# Altium schematic sheet Sheet1.SchDoc
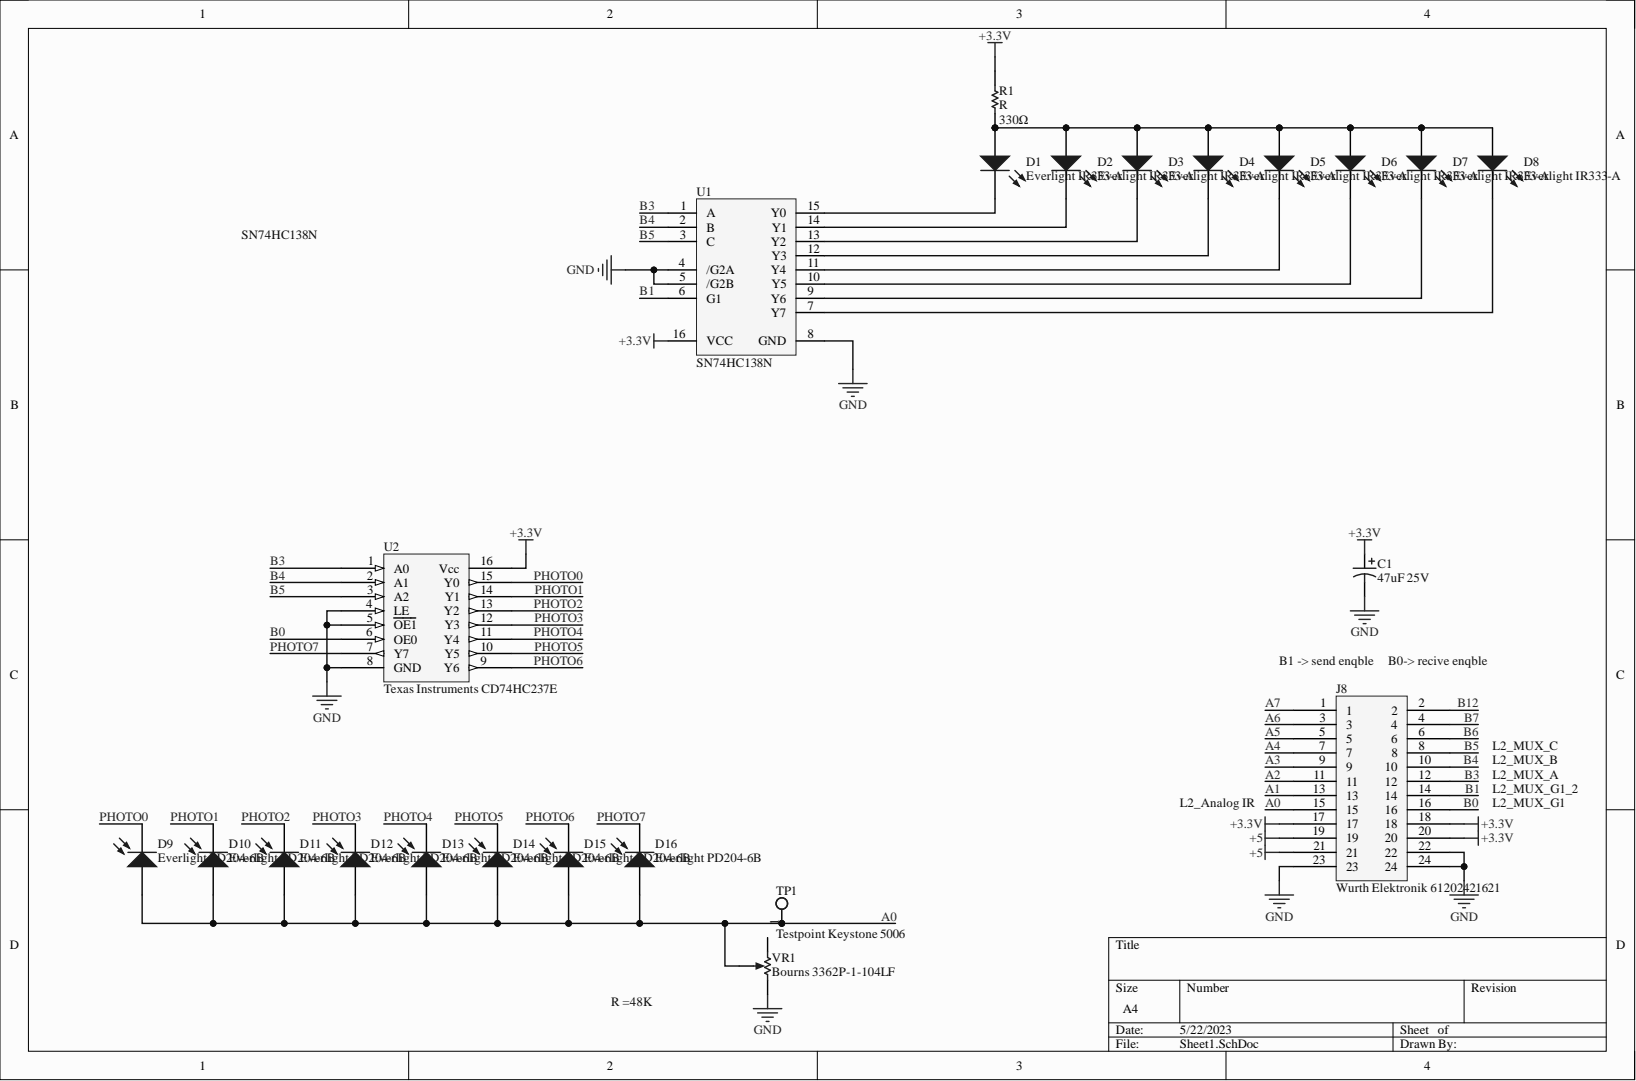
[diagram p0: Sheet1.SchDoc]

## Parts
C1  47uF 25V  [ELCO-6.3-12-2.5]
D1  Everlight IR333-A  [PCB-0002-02006-1]
D2  Everlight IR333-A  Manufacturer Part Number: IR333-A  [PCB-0002-02006-1]
D3  Everlight IR333-A  Manufacturer Part Number: IR333-A  [PCB-0002-02006-1]
D4  Everlight IR333-A  Manufacturer Part Number: IR333-A  [PCB-0002-02006-1]
D5  Everlight IR333-A  Manufacturer Part Number: IR333-A  [PCB-0002-02006-1]
D6  Everlight IR333-A  Manufacturer Part Number: IR333-A  [PCB-0002-02006-1]
D7  Everlight IR333-A  Manufacturer Part Number: IR333-A  [PCB-0002-02006-1]
D8  Everlight IR333-A  Manufacturer Part Number: IR333-A  [PCB-0002-02006-1]
D9  Everlight PD204-6B  [PCB-o05zkobulbt54tiv6vow-1]
D10  Everlight PD204-6B  Manufacturer Part Number: PD204-6B  [PCB-o05zkobulbt54tiv6vow-1]
D11  Everlight PD204-6B  Manufacturer Part Number: PD204-6B  [PCB-o05zkobulbt54tiv6vow-1]
D12  Everlight PD204-6B  Manufacturer Part Number: PD204-6B  [PCB-o05zkobulbt54tiv6vow-1]
D13  Everlight PD204-6B  Manufacturer Part Number: PD204-6B  [PCB-o05zkobulbt54tiv6vow-1]
D14  Everlight PD204-6B  Manufacturer Part Number: PD204-6B  [PCB-o05zkobulbt54tiv6vow-1]
D15  Everlight PD204-6B  Manufacturer Part Number: PD204-6B  [PCB-o05zkobulbt54tiv6vow-1]
D16  Everlight PD204-6B  Manufacturer Part Number: PD204-6B  [PCB-o05zkobulbt54tiv6vow-1]
J8  Wurth Elektronik 61202421621  Manufacturer Part Number: 61202421621  [Wurth Elektronik 61202421621-Footprint-1]
R1  R  Resistance: 330Ω  [PCB-q66ntzze7gytxksj4v9p-1]
TP1  Testpoint Keystone 5006  [PCB-a6m4wwq1w8vztsn322mx-1]
U1  SN74HC138N  [PCC-0018-00354-1]
U2  Texas Instruments CD74HC237E  Manufacturer Part Number: CD74HC237E  [PCB-xn33fkvk0f55jag7q4eg-1]
VR1  Bourns 3362P-1-104LF  [BOUR-3362P]

## Nets
+3.3V: C1.1 J8.17 J8.18 J8.20 R1.2 U1.16(VCC) U2.16(Vcc)
+5: J8.19 J8.21
GND: C1.2 J8.22 J8.23 J8.24 U1.4(/G2A) U1.5(/G2B) U1.8(GND) U2.4(LE) U2.5(OE1) U2.8(GND) VR1.3
A0: D9.1 D10.1 D11.1 D12.1 D13.1 D14.1 D15.1 D16.1 J8.15 TP1.1 VR1.2
A1: J8.13
A2: J8.11
A3: J8.9
A4: J8.7
A5: J8.5
A6: J8.3
A7: J8.1
B0: J8.16 U2.6(OE0)
B1: J8.14 U1.6(G1)
B3: J8.12 U1.1(A) U2.1(A0)
B4: J8.10 U1.2(B) U2.2(A1)
B5: J8.8 U1.3(C) U2.3(A2)
B6: J8.6
B7: J8.4
B12: J8.2
PHOTO0: D9.2 U2.15(Y0)
PHOTO1: D10.2 U2.14(Y1)
PHOTO2: D11.2 U2.13(Y2)
PHOTO3: D12.2 U2.12(Y3)
PHOTO4: D13.2 U2.11(Y4)
PHOTO5: D14.2 U2.10(Y5)
PHOTO6: D15.2 U2.9(Y6)
PHOTO7: D16.2 U2.7(Y7)
NetD1_1: D1.1 D2.1 D3.1 D4.1 D5.1 D6.1 D7.1 D8.1 R1.1
NetD1_2: D1.2 U1.15(Y0)
NetD2_2: D2.2 U1.14(Y1)
NetD3_2: D3.2 U1.13(Y2)
NetD4_2: D4.2 U1.12(Y3)
NetD5_2: D5.2 U1.11(Y4)
NetD6_2: D6.2 U1.10(Y5)
NetD7_2: D7.2 U1.9(Y6)
NetD8_2: D8.2 U1.7(Y7)

Not connected: VR1 1

## Library data of the parts
C1 = CMP-f0e23b7c3dc72f25-3: 150uF 6.3mm diameter 2.5mm lead spacing; Manufacturer Part Number: ECE-A1EKA470I; Capacitance: 47.0 µF; Capacitance Tolerance: ±20%; Lead-Free Status: Lead Free; Voltage Rating (DC): 25.0 V; Component Kind: Standard; Library Name: My Personal Space; Lifecycle Status: Not Listed by Manufacturer; Octopart Url: https://octopart.com/ece-a1eka470i-panasonic-43313219; OctopartID: f0e23b7c3dc72f25; Operating Temperature: -40.0 °C (min); Packaging: Cut Tape (CT); REACH SVHC Compliance: No SVHC; RoHS: Compliant; Size-Diameter: 6.30 mm; Size-Height: 7.00 mm
D1-D8 = CMP-906a59dde8874fad-1: 940 nm Viewing Angle 20° 100 mA Through Hole Plastic Infrared Emitting Diode; Lead-Free Status: Lead Free; Component Kind: Standard; Library Name: My Personal Space; Octopart URL: http://octopart.com/ir333-a-everlight-12410251; OctopartID: 906a59dde8874fad; RoHS: Compliant
  D1: Manufacturer Part Number: IR333-A
D9-D16 = CMP-5b29929d78a384ee-2: PD204 Series 6 ns 150 mW Photodiode Through Hole Photodetectors - RADIAL; Lead-Free Status: Lead Free; Component Kind: Standard; Library Name: My Personal Space; Mounting Style: Through Hole; Octopart Url: https://octopart.com/pd204-6b-everlight-12453612; OctopartID: 5b29929d78a384ee; RoHS: Compliant
  D9: Manufacturer Part Number: PD204-6B
J8 = CMP-5c4c94b32c98838b-3: WR-BHD Straight Male, Box Header, Through Hole, 2 Rows, 24 Contacts, 2.54mm Pitch; Contact Resistance: 20.0 mΩ; Dielectric Withstanding Voltage: 500 V; Number of Contacts: 24; Operating Voltage: 250 V; Color: Black; Component Kind: Standard; Contact Material: Copper; Contact Plating: Gold; Gender: Male; Library Name: My Personal Space; Mounting Style: Through Hole; Number of Pins: 24; Octopart Url: https://octopart.com/61202421621-wurth+elektronik-32855474; OctopartID: 5c4c94b32c98838b; Operating Temperature: -40.0 °C (min); Orientation: Vertical; Packaging: Tray; Pin Pitch: 2.54 mm; REACH SVHC Compliance: No SVHC; RoHS: Compliant
R1 = CMP-d70a1ef5a19f08cd-8: Res Metal Film 1K Ohm 1% 3/5W ±50ppm/°C Conformal AXL Thru-Hole Ammo Pack; Component Kind: Standard; Library Name: My Personal Space; Mounting Style: Axial; OctopartID: d70a1ef5a19f08cd; Resistance Tolerance: ±1%
TP1 = CMP-eb34223ee69c34f3-28: Testpoint 1mm Hole; Manufacturer Part Number: 5006; Lead-Free Status: Lead Free; Color: Black; Component Kind: Standard; Contact Material: Phosphor Bronze; Library Name: My Personal Space; Mounting Style: Through Hole, PC Board, PCB; Octopart URL: https://octopart.com/5006-keystone-10446; OctopartID: eb34223ee69c34f3; Packaging: Bulk; REACH SVHC Compliance: No SVHC; RoHS: Compliant
U1 = CMP-bf4f7645a8fe6088-3: Decoder/Demultiplexer Single 3-to-8 16-Pin DIP Decoder/Demultiplexer Single 3-to-8 16-Pin DIP; Manufacturer Part Number: SN74HC138D; Bandwidth: 28.0 MHz; Lead-Free Status: Lead Free; Number of Bits: 8; Number of Circuits: 1; Output Current Drive: -1.00 mA; Supply Voltage (DC): 2.00 V to 6.00 V; Voltage Nodes: 2.00 V, 6.00 V, 5.00 V; Case/Package: PDIP, SOIC; Component Kind: Standard; Library Name: My Personal Space; Lifecycle Status: Active; Mounting Style: Surface Mount; Number of Outputs: 8; Number of Pins: 16; Octopart URL: https://octopart.com/sn74hc138d-texas+instruments-13015; OctopartID: bf4f7645a8fe6088; Operating Temperature: -40.0 °C to 85.0 °C; Packaging: Tube, Rail; REACH SVHC Compliance: No SVHC; RoHS: Compliant
U2 = CMP-a1eb68eb68857e2c-2: High Speed CMOS Logic 3-to-8 Line Decoder Demutiplexer with Address Latches 16-PDIP -55 to 125; Bandwidth: 28.0 MHz; Lead-Free Status: Lead Free; Number of Bits: 8; Supply Voltage (DC): 2.00 V to 6.00 V; Voltage Nodes: 2.00 V, 6.00 V, 4.50 V; Case/Package: PDIP, SOIC; Component Kind: Standard; Library Name: My Personal Space; Lifecycle Status: Active; Mounting Style: Through Hole; Number of Outputs: 8; Number of Pins: 16; Octopart URL: https://octopart.com/cd74hc237e-texas+instruments-715784; OctopartID: a1eb68eb68857e2c; Operating Temperature: -55.0 °C to 125 °C; Packaging: Tube; REACH SVHC Compliance: No SVHC; RoHS: Compliant
VR1 = CMP-9f905698f6564057-4: Square Trimpot(R) Trimming Potentiometer, 100 KOhm, 300 V, -55 to 125 degC, 3-Pin THD, RoHS, Tube; Component Kind: Standard; Library Name: My Personal Space
